# Altium schematic sheet SCM20260D-DIMM.SchDoc
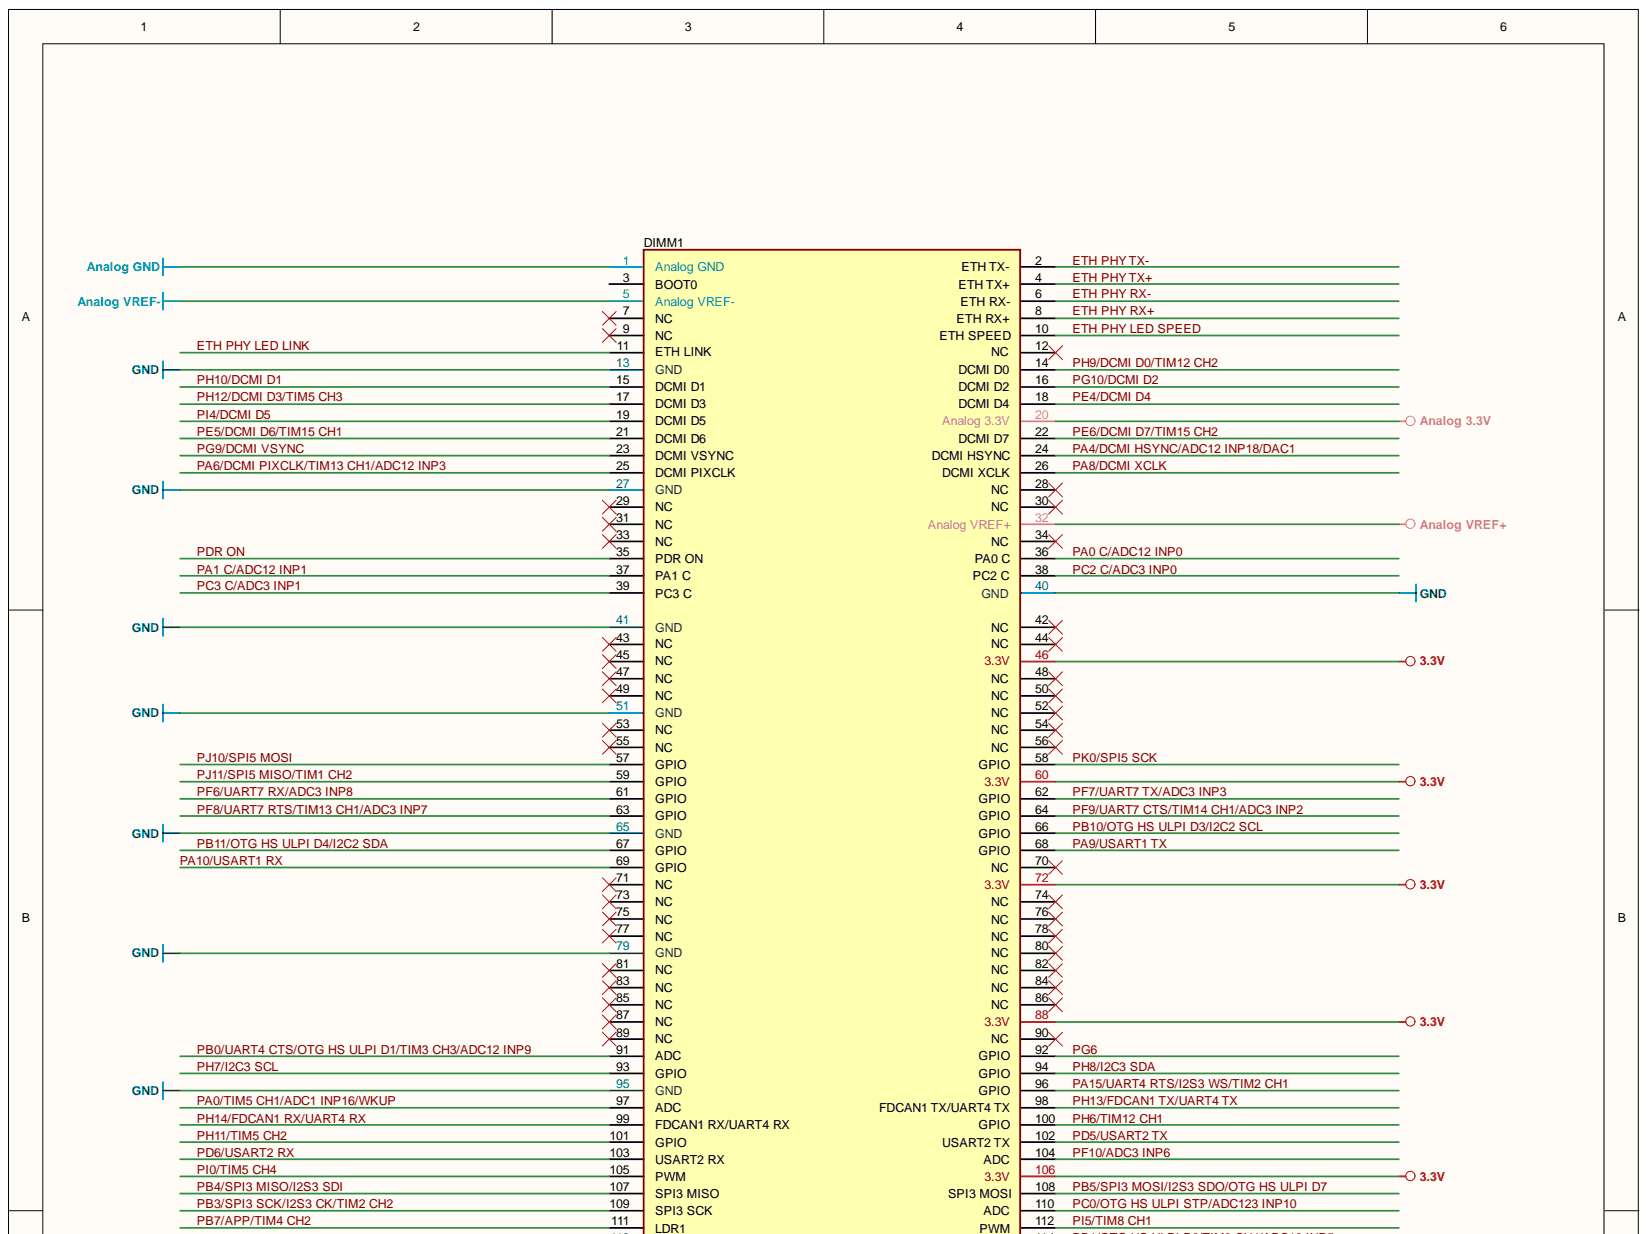
[diagram p0: SCM20260D-DIMM.SchDoc, top]
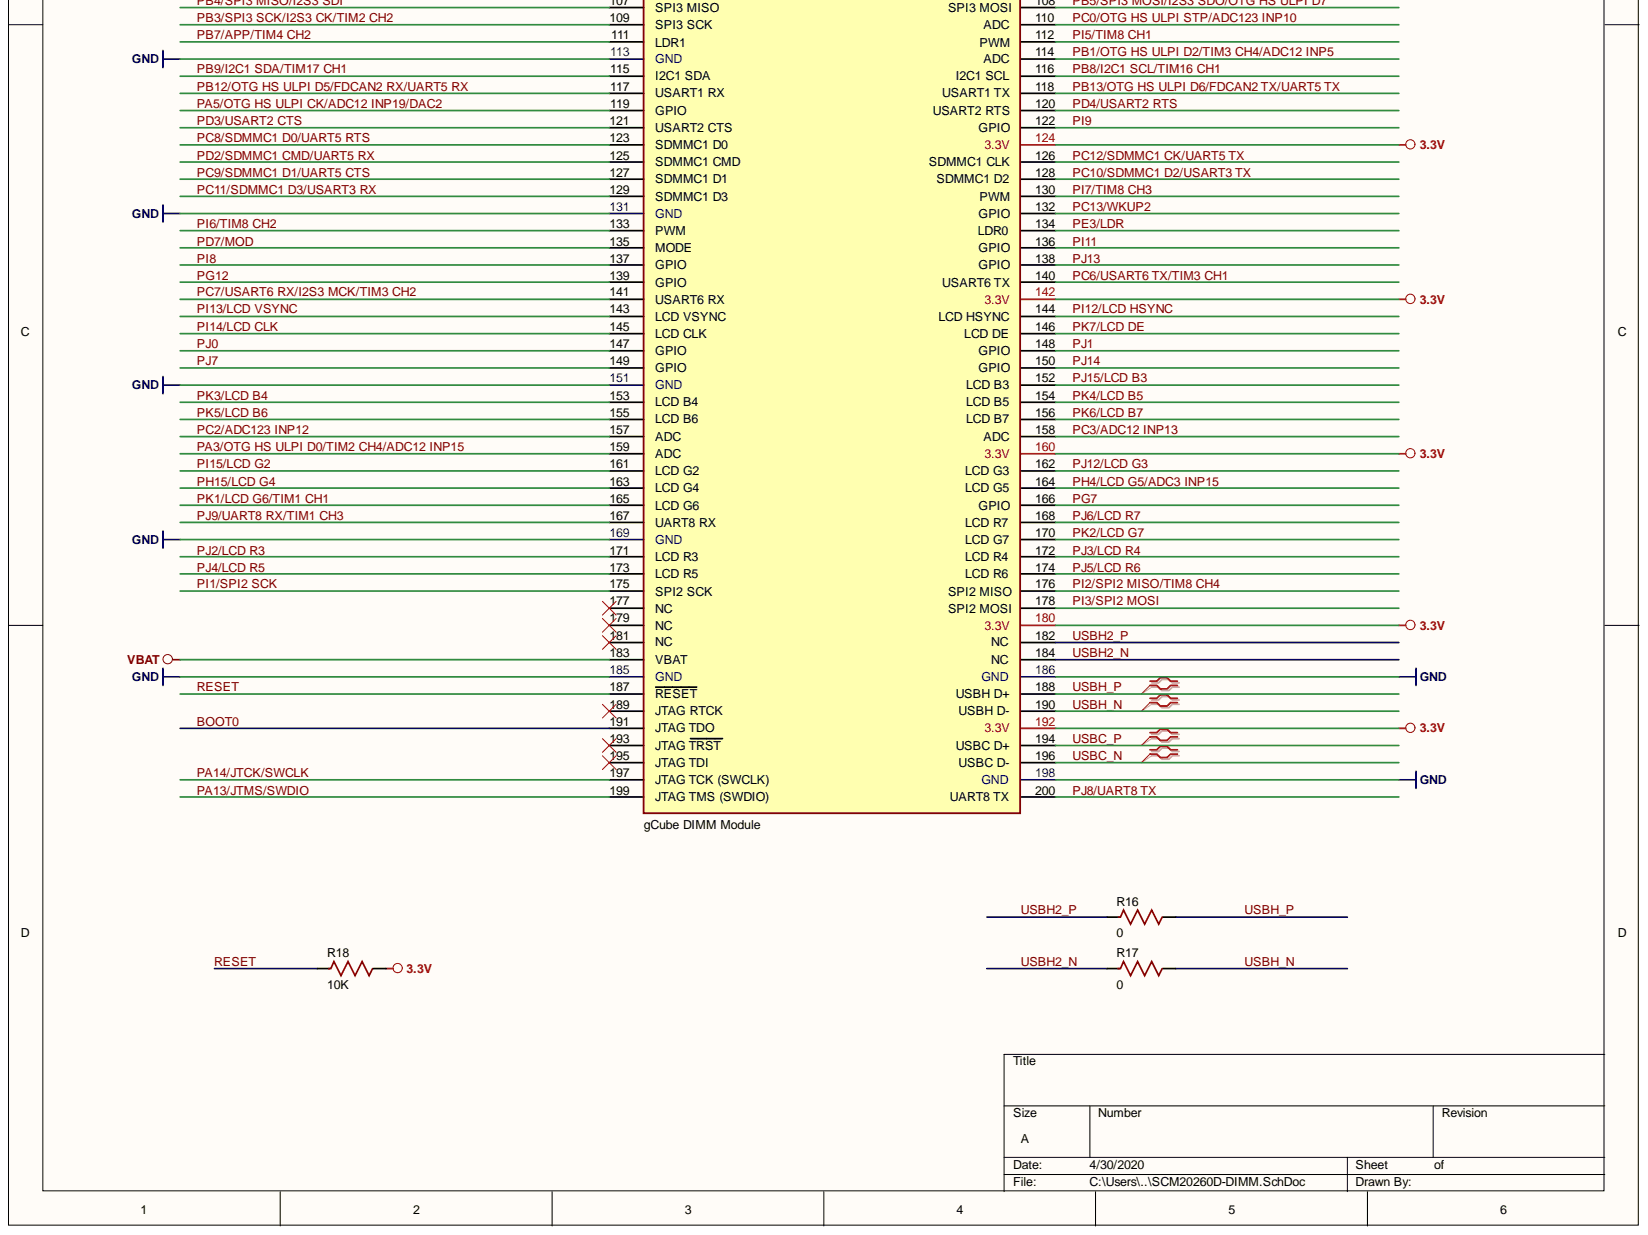
[diagram p1: SCM20260D-DIMM.SchDoc, bottom]

## Parts
DIMM1  gCube DIMM Module  [SODIMM-GF-2D-600-1K-S200]  (no BOM)
R16  value 0  [R0402]  (hidden comment: 0402 Res 0)
R17  value 0  [R0402]  (hidden comment: 0402 Res 0)
R18  value 10K  Resistance: 10K  [R0402]  (hidden comment: 0402 Res 10K)

## Nets
3.3V: DIMM1.46(3.3V) DIMM1.60(3.3V) DIMM1.72(3.3V) DIMM1.88(3.3V) DIMM1.106(3.3V) DIMM1.124(3.3V) DIMM1.142(3.3V) DIMM1.160(3.3V) DIMM1.180(3.3V) DIMM1.192(3.3V) R18.2
Analog 3.3V: DIMM1.20(Analog_3.3V)
Analog GND: DIMM1.1(Analog_GND)
Analog VREF+: DIMM1.32(Analog_VREF+)
Analog VREF-: DIMM1.5(Analog_VREF-)
GND: DIMM1.13(GND) DIMM1.27(GND) DIMM1.40(GND) DIMM1.41(GND) DIMM1.51(GND) DIMM1.65(GND) DIMM1.79(GND) DIMM1.95(GND) DIMM1.113(GND) DIMM1.131(GND) DIMM1.151(GND) DIMM1.169(GND) DIMM1.185(GND) DIMM1.186(GND) DIMM1.198(GND)
VBAT: DIMM1.183(VBAT)
BOOT0: DIMM1.191(JTAG_TDO)
ETH PHY LED LINK: DIMM1.11(ETH_LINK)
ETH PHY LED SPEED: DIMM1.10(ETH_SPEED)
ETH PHY RX+: DIMM1.8(ETH_RX+)
ETH PHY RX-: DIMM1.6(ETH_RX-)
ETH PHY TX+: DIMM1.4(ETH_TX+)
ETH PHY TX-: DIMM1.2(ETH_TX-)
PA0 C/ADC12 INP0: DIMM1.36(PA0_C)
PA0/TIM5 CH1/ADC1 INP16/WKUP: DIMM1.97(ADC)
PA1 C/ADC12 INP1: DIMM1.37(PA1_C)
PA3/OTG HS ULPI D0/TIM2 CH4/ADC12 INP15: DIMM1.159(ADC)
PA4/DCMI HSYNC/ADC12 INP18/DAC1: DIMM1.24(DCMI_HSYNC)
PA5/OTG HS ULPI CK/ADC12 INP19/DAC2: DIMM1.119(GPIO)
PA6/DCMI PIXCLK/TIM13 CH1/ADC12 INP3: DIMM1.25(DCMI_PIXCLK)
PA8/DCMI XCLK: DIMM1.26(DCMI_XCLK)
PA9/USART1 TX: DIMM1.68(GPIO)
PA10/USART1 RX: DIMM1.69(GPIO)
PA13/JTMS/SWDIO: DIMM1.199(JTAG_TMS_(SWDIO))
PA14/JTCK/SWCLK: DIMM1.197(JTAG_TCK_(SWCLK))
PA15/UART4 RTS/I2S3 WS/TIM2 CH1: DIMM1.96(GPIO)
PB0/UART4 CTS/OTG HS ULPI D1/TIM3 CH3/ADC12 INP9: DIMM1.91(ADC)
PB1/OTG HS ULPI D2/TIM3 CH4/ADC12 INP5: DIMM1.114(ADC)
PB3/SPI3 SCK/I2S3 CK/TIM2 CH2: DIMM1.109(SPI3_SCK)
PB4/SPI3 MISO/I2S3 SDI: DIMM1.107(SPI3_MISO)
PB5/SPI3 MOSI/I2S3 SDO/OTG HS ULPI D7: DIMM1.108(SPI3_MOSI)
PB7/APP/TIM4 CH2: DIMM1.111(LDR1)
PB8/I2C1 SCL/TIM16 CH1: DIMM1.116(I2C1_SCL)
PB9/I2C1 SDA/TIM17 CH1: DIMM1.115(I2C1_SDA)
PB10/OTG HS ULPI D3/I2C2 SCL: DIMM1.66(GPIO)
PB11/OTG HS ULPI D4/I2C2 SDA: DIMM1.67(GPIO)
PB12/OTG HS ULPI D5/FDCAN2 RX/UART5 RX: DIMM1.117(USART1_RX)
PB13/OTG HS ULPI D6/FDCAN2 TX/UART5 TX: DIMM1.118(USART1_TX)
PC0/OTG HS ULPI STP/ADC123 INP10: DIMM1.110(ADC)
PC2 C/ADC3 INP0: DIMM1.38(PC2_C)
PC2/ADC123 INP12: DIMM1.157(ADC)
PC3 C/ADC3 INP1: DIMM1.39(PC3_C)
PC3/ADC12 INP13: DIMM1.158(ADC)
PC6/USART6 TX/TIM3 CH1: DIMM1.140(USART6_TX)
PC7/USART6 RX/I2S3 MCK/TIM3 CH2: DIMM1.141(USART6_RX)
PC8/SDMMC1 D0/UART5 RTS: DIMM1.123(SDMMC1_D0)
PC9/SDMMC1 D1/UART5 CTS: DIMM1.127(SDMMC1_D1)
PC10/SDMMC1 D2/USART3 TX: DIMM1.128(SDMMC1_D2)
PC11/SDMMC1 D3/USART3 RX: DIMM1.129(SDMMC1_D3)
PC12/SDMMC1 CK/UART5 TX: DIMM1.126(SDMMC1_CLK)
PC13/WKUP2: DIMM1.132(GPIO)
PD2/SDMMC1 CMD/UART5 RX: DIMM1.125(SDMMC1_CMD)
PD3/USART2 CTS: DIMM1.121(USART2_CTS)
PD4/USART2 RTS: DIMM1.120(USART2_RTS)
PD5/USART2 TX: DIMM1.102(USART2_TX)
PD6/USART2 RX: DIMM1.103(USART2_RX)
PD7/MOD: DIMM1.135(MODE)
PDR ON: DIMM1.35(PDR_ON)
PE3/LDR: DIMM1.134(LDR0)
PE4/DCMI D4: DIMM1.18(DCMI_D4)
PE5/DCMI D6/TIM15 CH1: DIMM1.21(DCMI_D6)
PE6/DCMI D7/TIM15 CH2: DIMM1.22(DCMI_D7)
PF6/UART7 RX/ADC3 INP8: DIMM1.61(GPIO)
PF7/UART7 TX/ADC3 INP3: DIMM1.62(GPIO)
PF8/UART7 RTS/TIM13 CH1/ADC3 INP7: DIMM1.63(GPIO)
PF9/UART7 CTS/TIM14 CH1/ADC3 INP2: DIMM1.64(GPIO)
PF10/ADC3 INP6: DIMM1.104(ADC)
PG6: DIMM1.92(GPIO)
PG7: DIMM1.166(GPIO)
PG9/DCMI VSYNC: DIMM1.23(DCMI_VSYNC)
PG10/DCMI D2: DIMM1.16(DCMI_D2)
PG12: DIMM1.139(GPIO)
PH4/LCD G5/ADC3 INP15: DIMM1.164(LCD_G5)
PH6/TIM12 CH1: DIMM1.100(GPIO)
PH7/I2C3 SCL: DIMM1.93(GPIO)
PH8/I2C3 SDA: DIMM1.94(GPIO)
PH9/DCMI D0/TIM12 CH2: DIMM1.14(DCMI_D0)
PH10/DCMI D1: DIMM1.15(DCMI_D1)
PH11/TIM5 CH2: DIMM1.101(GPIO)
PH12/DCMI D3/TIM5 CH3: DIMM1.17(DCMI_D3)
PH13/FDCAN1 TX/UART4 TX: DIMM1.98(FDCAN1_TX/UART4_TX)
PH14/FDCAN1 RX/UART4 RX: DIMM1.99(FDCAN1_RX/UART4_RX)
PH15/LCD G4: DIMM1.163(LCD_G4)
PI0/TIM5 CH4: DIMM1.105(PWM)
PI1/SPI2 SCK: DIMM1.175(SPI2_SCK)
PI2/SPI2 MISO/TIM8 CH4: DIMM1.176(SPI2_MISO)
PI3/SPI2 MOSI: DIMM1.178(SPI2_MOSI)
PI4/DCMI D5: DIMM1.19(DCMI_D5)
PI5/TIM8 CH1: DIMM1.112(PWM)
PI6/TIM8 CH2: DIMM1.133(PWM)
PI7/TIM8 CH3: DIMM1.130(PWM)
PI8: DIMM1.137(GPIO)
PI9: DIMM1.122(GPIO)
PI11: DIMM1.136(GPIO)
PI12/LCD HSYNC: DIMM1.144(LCD_HSYNC)
PI13/LCD VSYNC: DIMM1.143(LCD_VSYNC)
PI14/LCD CLK: DIMM1.145(LCD_CLK)
PI15/LCD G2: DIMM1.161(LCD_G2)
PJ0: DIMM1.147(GPIO)
PJ1: DIMM1.148(GPIO)
PJ2/LCD R3: DIMM1.171(LCD_R3)
PJ3/LCD R4: DIMM1.172(LCD_R4)
PJ4/LCD R5: DIMM1.173(LCD_R5)
PJ5/LCD R6: DIMM1.174(LCD_R6)
PJ6/LCD R7: DIMM1.168(LCD_R7)
PJ7: DIMM1.149(GPIO)
PJ8/UART8 TX: DIMM1.200(UART8_TX)
PJ9/UART8 RX/TIM1 CH3: DIMM1.167(UART8_RX)
PJ10/SPI5 MOSI: DIMM1.57(GPIO)
PJ11/SPI5 MISO/TIM1 CH2: DIMM1.59(GPIO)
PJ12/LCD G3: DIMM1.162(LCD_G3)
PJ13: DIMM1.138(GPIO)
PJ14: DIMM1.150(GPIO)
PJ15/LCD B3: DIMM1.152(LCD_B3)
PK0/SPI5 SCK: DIMM1.58(GPIO)
PK1/LCD G6/TIM1 CH1: DIMM1.165(LCD_G6)
PK2/LCD G7: DIMM1.170(LCD_G7)
PK3/LCD B4: DIMM1.153(LCD_B4)
PK4/LCD B5: DIMM1.154(LCD_B5)
PK5/LCD B6: DIMM1.155(LCD_B6)
PK6/LCD B7: DIMM1.156(LCD_B7)
PK7/LCD DE: DIMM1.146(LCD_DE)
RESET: DIMM1.187(RESET) R18.1
USBC_N: DIMM1.196(USBC_D-)
USBC_P: DIMM1.194(USBC_D+)
USBH2_N: DIMM1.184(NC) R17.1
USBH2_P: DIMM1.182(NC) R16.1
USBH_N: DIMM1.190(USBH_D-) R17.2
USBH_P: DIMM1.188(USBH_D+) R16.2

Not connected: DIMM1 3(BOOT0) 7(NC) 9(NC) 12(NC) 28(NC) 29(NC) 30(NC) 31(NC) 33(NC) 34(NC) 42(NC) 43(NC) 44(NC) 45(NC) 47(NC) 48(NC) 49(NC) 50(NC) 52(NC) 53(NC) 54(NC) 55(NC) 56(NC) 70(NC) 71(NC) 73(NC) 74(NC) 75(NC) 76(NC) 77(NC) 78(NC) 80(NC) 81(NC) 82(NC) 83(NC) 84(NC) 85(NC) 86(NC) 87(NC) 89(NC) 90(NC) 177(NC) 179(NC) 181(NC) 189(JTAG_RTCK) 193(JTAG_TRST) 195(JTAG_TDI)

## Library data of the parts
DIMM1 = gCube DIMM Module: gCube DIMM Module Schematic Symbol; ClassName: Edge Connector; Library Name: GHI PCB Base.SchLib
R16 R17 = 0402 Res 0: ±5% 1/16W 0402 Chip Resistor - Surface Mount RoHS; Manufacturer: YAGEO; Mfr.Part #: RC0402JR-070RL; Voltage Rating: *V; Component Kind: Standard; LCSC Part #: C60485; Package: 0402; Power Rating: 1/16W; Resistance: 0; Resistance Tolerance: ±5%; Library Name: GHI-Passives.SchLib
R18 = 0402 Res 10K: 10K ±1% 1/16W ±100ppm/℃ 0402 Chip Resistor - Surface Mount RoHS; Manufacturer: YAGEO; Mfr.Part #: RC0402FR-0710KL; Voltage Rating: *V; Component Kind: Standard; LCSC Part #: C60490; Package: 0402; Power Rating: 1/16W; Resistance Tolerance: ±1%; Library Name: GHI-Passives.SchLib
